# Altium schematic sheet HUB.SchDoc(HUB)
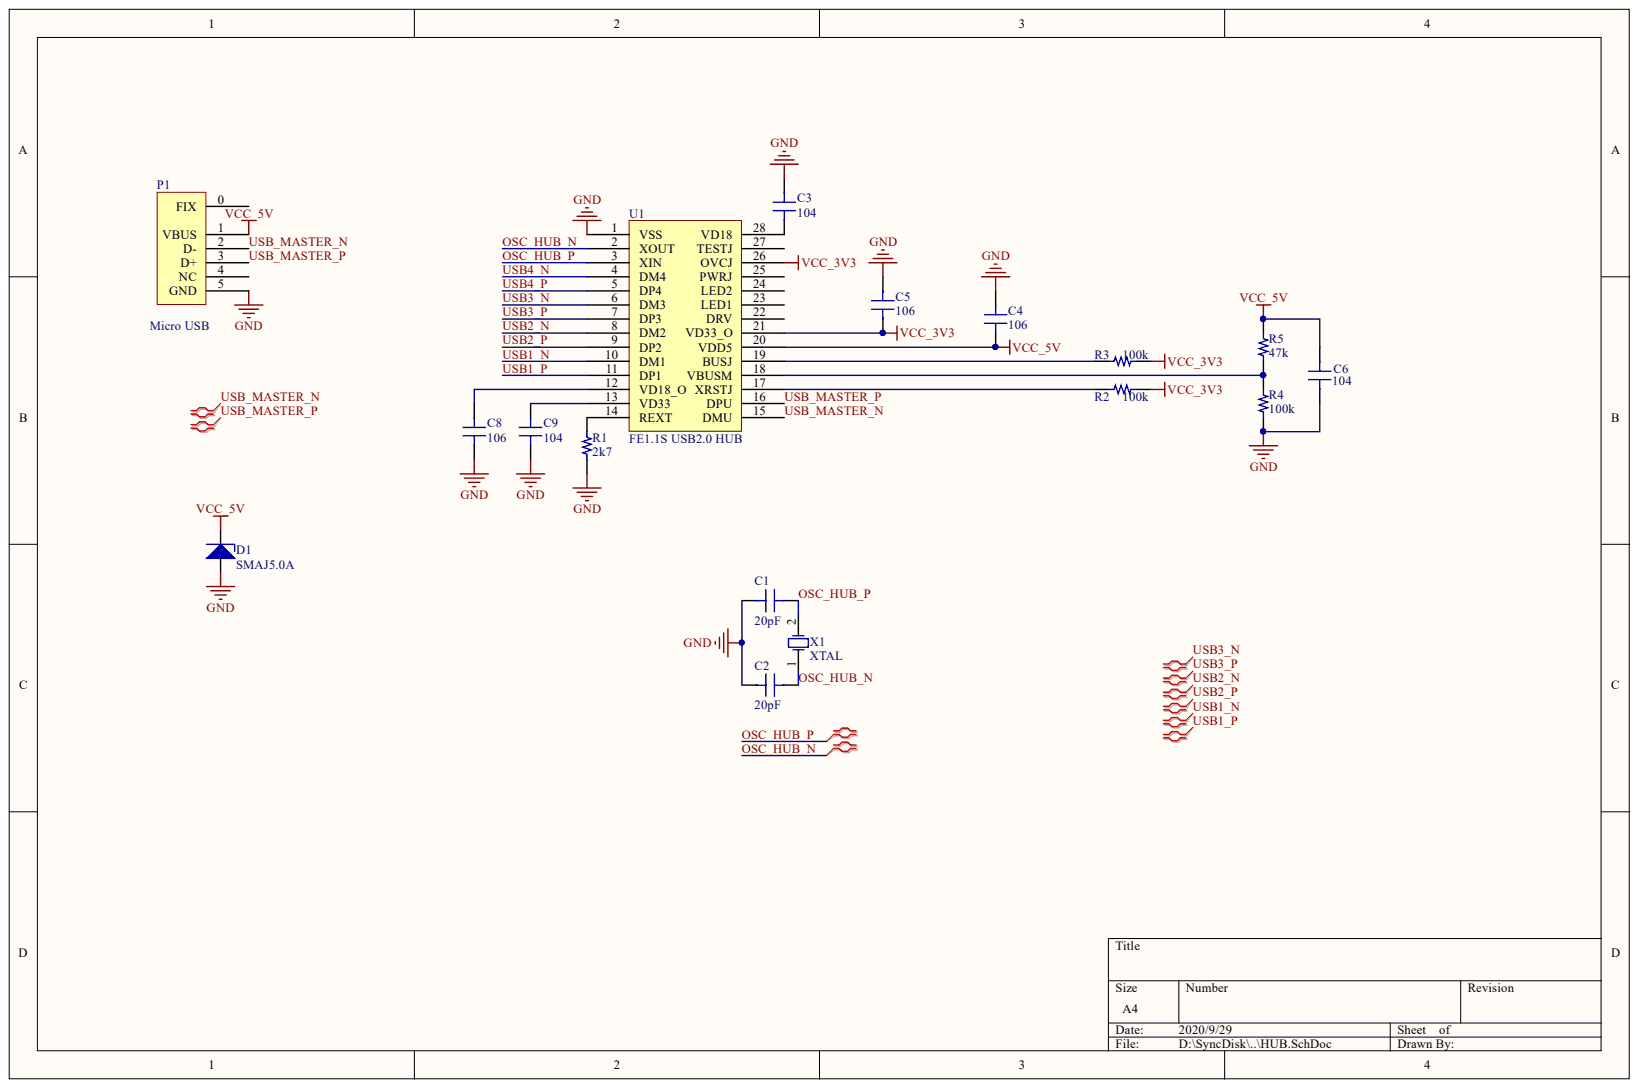
[diagram p0: HUB.SchDoc]

## Parts
C1  20pF  [C0603/1608]
C2  20pF  [C0603/1608]
C3  104  [C0603/1608]
C4  106  [C0603/1608]
C5  106  [C0603/1608]
C6  104  [C0603/1608]
C8  106  [C0603/1608]
C9  104  [C0603/1608]
D1  SMAJ5.0A  [DO-214AC/SMA]
P1  Micro USB  [Micro USB]
R1  2k7  [R0603/1608]
R2  100k  [R0603/1608]
R3  100k  [R0603/1608]
R4  100k  [R0603/1608]
R5  47k  [R0603/1608]
U1  FE1.1S USB2.0 HUB  [SOP65P780-28M]
X1  XTAL  [XTAL-49S]

## Nets
GND: C1.2 C2.2 C3.1 C4.1 C5.1 C6.2 C8.2 C9.2 D1.1 P1.5(GND) R1.1 R4.2 U1.1(VSS)
VCC_3V3: C5.2 R2.1 R3.1 U1.21(VD33_O) U1.26(OVCJ)
VCC_5V: C4.2 C6.1 D1.2 P1.1(VBUS) R5.1 U1.20(VDD5)
OSC_HUB_N: C2.1 U1.2(XOUT) X1.1
OSC_HUB_P: C1.1 U1.3(XIN) X1.2
USB1_N: U1.10(DM1)
USB1_P: U1.11(DP1)
USB2_N: U1.8(DM2)
USB2_P: U1.9(DP2)
USB3_N: U1.6(DM3)
USB3_P: U1.7(DP3)
USB4_N: U1.4(DM4)
USB4_P: U1.5(DP4)
USB_MASTER_N: P1.2(D-) U1.15(DMU)
USB_MASTER_P: P1.3(D+) U1.16(DPU)
NetC3_2: C3.2 U1.28(VD18)
NetC8_1: C8.1 U1.12(VD18_O)
NetC9_1: C9.1 U1.13(VD33)
NetR1_2: R1.2 U1.14(REXT)
NetR2_2: R2.2 U1.17(XRSTJ)
NetR3_2: R3.2 U1.19(BUSJ)
NetR4_1: R4.1 R5.2 U1.18(VBUSM)

Not connected: P1 0(FIX) 4(NC); U1 22(DRV) 23(LED1) 24(LED2) 25(PWRJ) 27(TESTJ)

## Library data of the parts
C1-C6 C8 C9 = 陶瓷电容: Component Kind: Standard; Description: 陶瓷电容; Library Name: Devices.SchLib
D1 = 瞬态抑制二极管: Component Kind: Standard; Description: 瞬态抑制二极管; Library Name: WMJ2019_Devices.SchLib
P1 = Micro USB: Micro USB底座; Component Kind: Standard; Library Name: Devices.SchLib
R1-R5 = 电阻: Component Kind: Standard; Description: 电阻; Library Name: Devices.SchLib
X1 = 晶振: Component Kind: Standard; Description: 晶振; LatestRevisionDate: 17-Jul-2002; LatestRevisionNote: Re-released for DXP Platform.; Library Name: Devices.SchLib; Published: 8-Jun-2000; Publisher: Altium Limited
U1 = FE1.1S USB2.0 HUB: Component Kind: Standard; Description: FE1.1S USB2.0 HUB; Library Name: Devices.SchLib
